# Altium schematic sheet PAGE2 - MCU.SchDoc
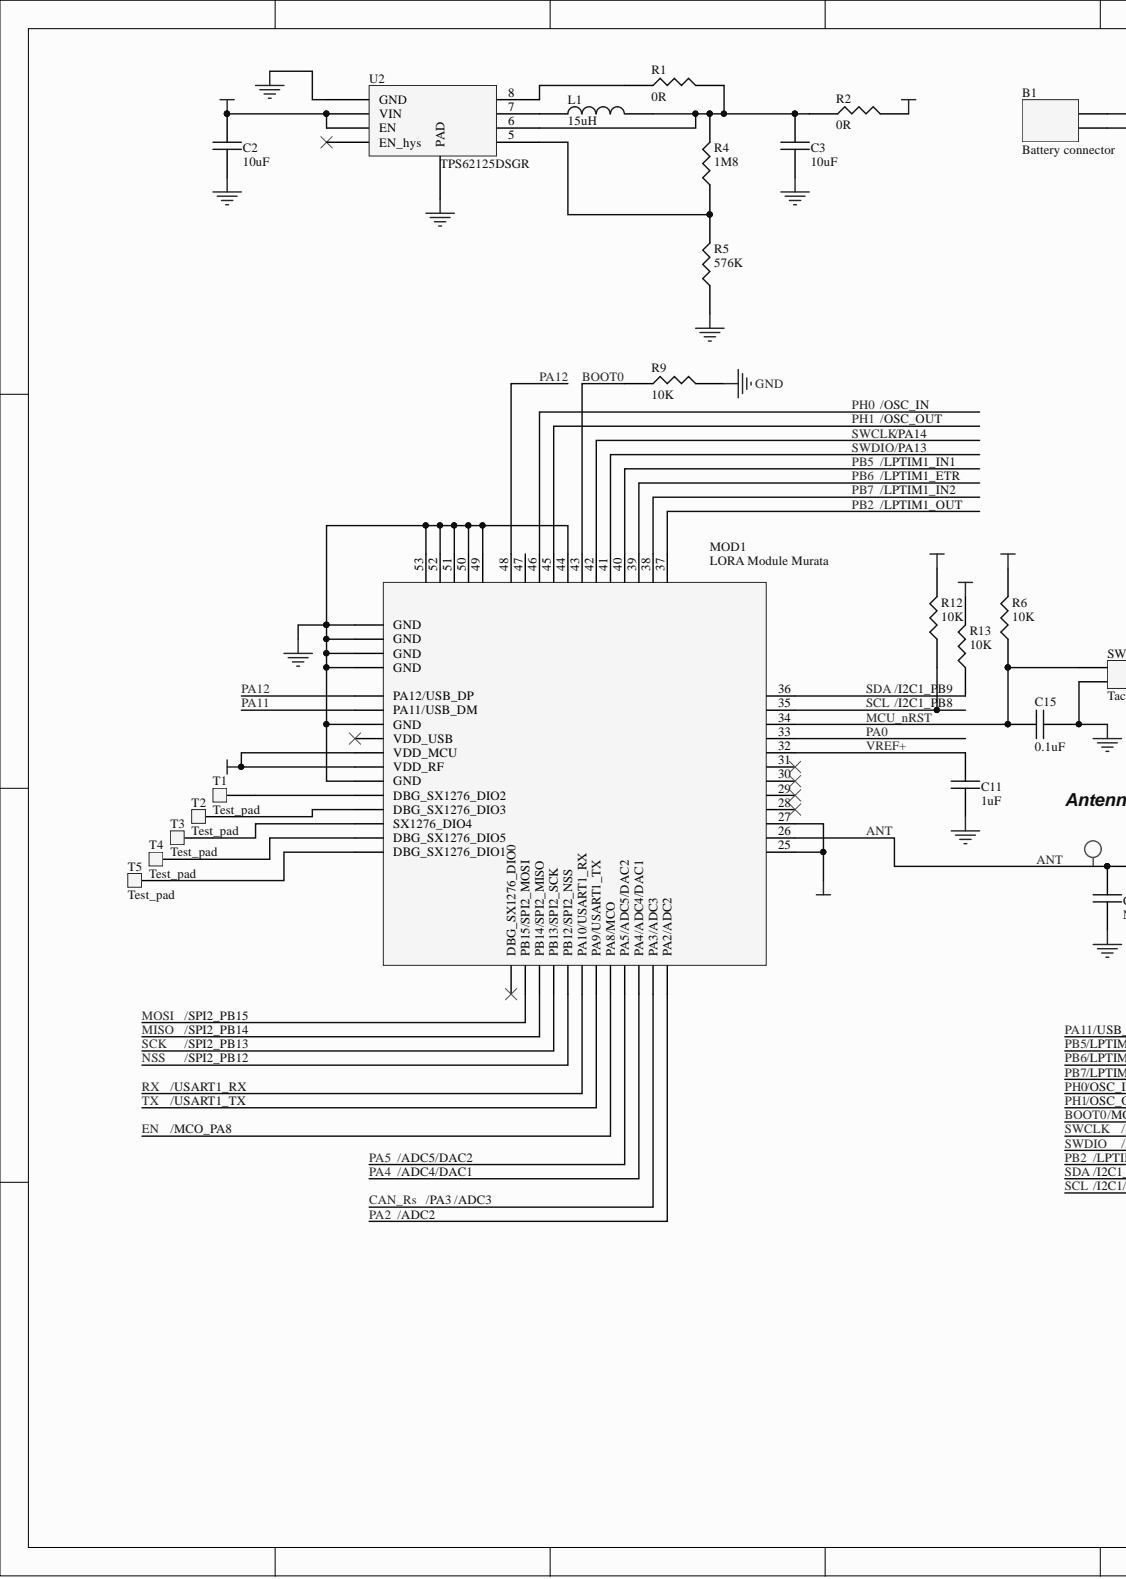
[diagram p0: PAGE2 - MCU.SchDoc, left]
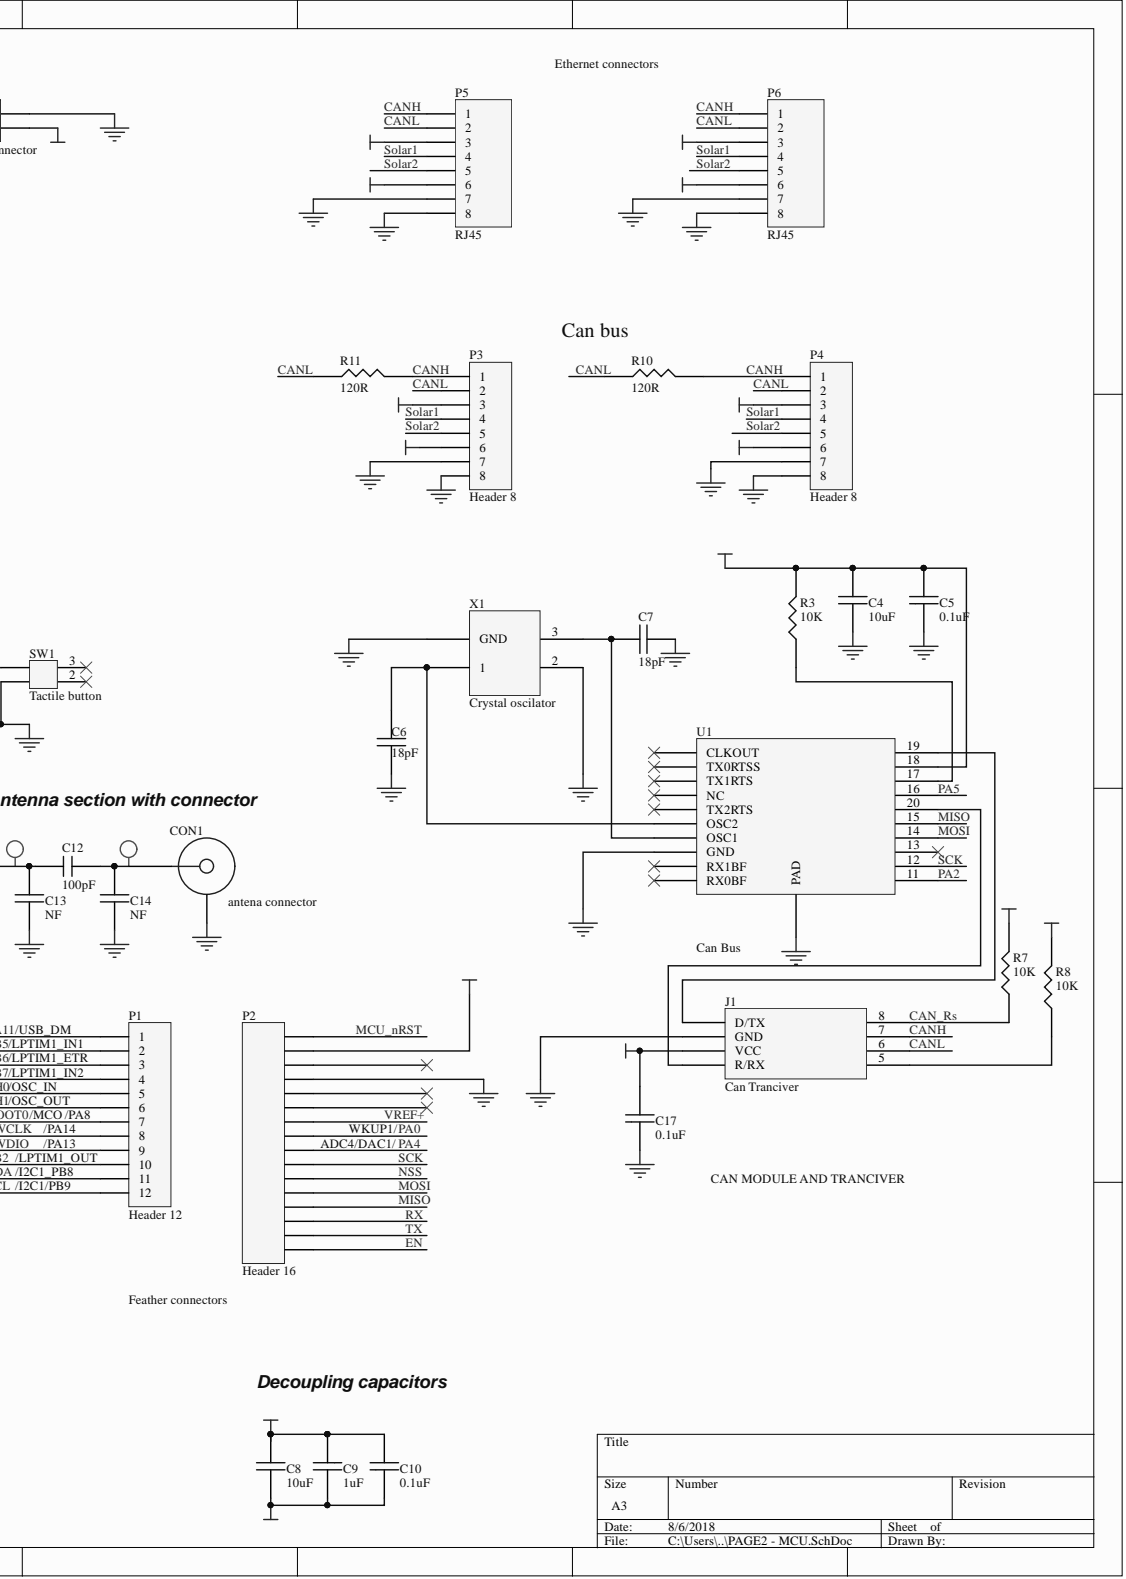
[diagram p1: PAGE2 - MCU.SchDoc, right]

## Parts
B1  Battery connector  [BAT_CON]
C2  10uF  [0603Cap]
C3  10uF  [0603Cap]
C4  10uF  [0603Cap]
C5  0.1uF  [0603Cap]
C6  18pF  [0603Cap]
C7  18pF  [0603Cap]
C8  10uF  [0603Cap]
C9  1uF  [0603Cap]
C10  0.1uF  [0603Cap]
C11  1uF  [0603Cap]
C12  100pF  [0402CAP]
C13  NF  [0402CAP]
C14  NF  [0402CAP]
C15  0.1uF  [0603Cap]
C17  0.1uF  [0603Cap]
CON1  antena connector  [uFL SMD CONNECTOR]
J1  Can Tranciver  [SOIC127-Can Tranciver]
L1  15uH  [Inductor 3.0x2.5]
MOD1  LORA Module Murata  Manufacturer: Murata  [Lora Module ES0]
P1  Header 12  [HDR1X12]
P2  Header 16  [HDR1X16]
P3  Header 8  [HDR1X8]
P4  Header 8  [HDR1X8]
P5  RJ45  [EThernet conn]
P6  RJ45  [EThernet conn]
R1  0R  [0603Res]
R2  0R  [0603Res]
R3  10K  [0603Res]
R4  1M8  [0603Res]
R5  576K  [0603Res]
R6  10K  [0603Res]
R7  10K  [0603Res]
R8  10K  [0603Res]
R9  10K  [0603Res]
R10  120R  [0603Res]
R11  120R  [0603Res]
R12  10K  [0603Res]
R13  10K  [0603Res]
SW1  Tactile button  [Tactile button]
T1  Test_pad  [Test_Pad]
T2  Test_pad  [Test_Pad]
T3  Test_pad  [Test_Pad]
T4  Test_pad  [Test_Pad]
T5  Test_pad  [Test_Pad]
U1  Can Bus  [QFN-Can Bus]
U2  TPS62125DSGR  [WSON-Voltage regulator]
X1  Crystal oscilator  [DFN-Crystal]

## Nets
+3V3: B1.1 C4.1 C5.2 C8.1 C9.1 C10.2 C17.2 J1.3(VCC) MOD1.5(VDD_MCU) MOD1.6(VDD_RF) P2.15 R2.2 R3.2 R6.2 R7.2 R8.2 R12.2 R13.2 U1.18
+12V: C2.1 P3.3 P3.6 P4.3 P4.6 P5.3 P5.6 P6.3 P6.6 U2.2(VIN) U2.3(EN)
GND: B1.2 C2.2 C3.2 C4.2 C5.1 C6.2 C7.1 C8.2 C9.2 C10.1 C11.1 C13.1 C14.1 C15.2 C17.1 CON1.2 J1.2(GND) MOD1.3(GND) MOD1.7(GND) MOD1.25 MOD1.27 MOD1.44 MOD1.49 MOD1.50 MOD1.51 MOD1.52 MOD1.53 MOD1.54(GND) MOD1.55(GND) MOD1.56(GND) MOD1.57(GND) P2.13 P3.7 P3.8 P4.7 P4.8 P5.7 P5.8 P6.7 P6.8 R5.1 R9.2 SW1.1 U1.8(GND) U1.21(PAD) U2.1(GND) U2.9(PAD) X1.2 X1.4(GND)
ANT: C12.1 C13.2 MOD1.26
BOOT0: MOD1.43 P1.7 R9.1
CAN_Rs: J1.8 MOD1.23(PA3/ADC3) R7.1
CANH: J1.7 P3.1 P4.1 P5.1 P6.1 R10.2 R11.2
CANL: J1.6 P3.2 P4.2 P5.2 P6.2 R10.1 R11.1
EN: MOD1.20(PA8/MCO) P2.1
MCU_nRST: C15.1 MOD1.34 P2.16 R6.1 SW1.4
MISO: MOD1.15(PB14/SPI2_MISO) P2.4 U1.15
MOSI: MOD1.14(PB15/SPI2_MOSI) P2.5 U1.14
NSS: MOD1.17(PB12/SPI2_NSS) P2.6
PA0: MOD1.33 P2.9
PA2: MOD1.24(PA2/ADC2) U1.11
PA4: MOD1.22(PA4/ADC4/DAC1) P2.8
PA5: MOD1.21(PA5/ADC5/DAC2) U1.16
PA11: MOD1.2(PA11/USB_DM) P1.1
PA12: MOD1.1(PA12/USB_DP) MOD1.48
PB2: MOD1.37 P1.10
PB5: MOD1.40 P1.2
PB6: MOD1.39 P1.3
PB7: MOD1.38 P1.4
PH0: MOD1.46 P1.5
PH1: MOD1.45 P1.6
RX: MOD1.18(PA10/USART1_RX) P2.3
SCK: MOD1.16(PB13/SPI2_SCK) P2.7 U1.12
SCL: MOD1.35 P1.12 R12.1
SDA: MOD1.36 P1.11 R13.1
Solar1: P3.4 P4.4 P5.4 P6.4
Solar2: P3.5 P4.5 P5.5 P6.5
SWCLK: MOD1.42 P1.8
SWDIO: MOD1.41 P1.9
TX: MOD1.19(PA9/USART1_TX) P2.2
VREF+: C11.2 MOD1.32 P2.10
NetC3_1: C3.1 L1.2 R1.2 R2.1 R4.2 U2.6
NetC6_1: C6.1 U1.6(OSC2) X1.1
NetC7_2: C7.2 U1.7(OSC1) X1.3
NetC12_2: C12.2 C14.2 CON1.1
NetJ1_1: J1.1(D/TX) U1.19
NetJ1_4: J1.4(R/RX) U1.20
NetJ1_5: J1.5 R8.1
NetL1_1: L1.1 U2.7
NetMOD1_8: MOD1.8(DBG_SX1276_DIO2) T1.1
NetMOD1_9: MOD1.9(DBG_SX1276_DIO3) T2.1
NetMOD1_10: MOD1.10(SX1276_DIO4) T3.1
NetMOD1_11: MOD1.11(DBG_SX1276_DIO5) T4.1
NetMOD1_12: MOD1.12(DBG_SX1276_DIO1) T5.1
NetR1_1: R1.1 U2.8
NetR3_1: R3.1 U1.17
NetR4_1: R4.1 R5.2 U2.5

Not connected: MOD1 4(VDD_USB) 13(DBG_SX1276_DIO0) 28 29 30 31 47; P2 11 12 14; SW1 2 3; U1 1(CLKOUT) 2(TX0RTSS) 3(TX1RTS) 4(NC) 5(TX2RTS) 9(RX1BF) 10(RX0BF) 13; U2 4(EN_hys)

## Library data of the parts
B1 = 1862: JST-PH 2-Pin SMT Right Angle Breakout Board; Manufacturer: Adafruit Industries; Component Kind: Standard; Manufacturer Part Number: 1862; Pricing 1: 1=1.5, 10=1.5, 50=1.5, 100=1.5, 1000=1.5, 10000=1.5 (USD); Stock 1: 115; Supplier 1: Mouser; Supplier Part Number 1: 485-1862; Library Name: Lora_feather.SchLib
C2-C4 C8 = 10uF Cap: MURATA         ZRB18AR61E106ME01L             SMD Multilayer Ceramic Capacitor, 0603 [1608 Metric], 10 F, 25 V,  20%, X5R, ZRA Series; Capacitance: 10µF; Voltage - Rated: 25V; Applications: Acoustic Noise Reduction; Category: Capacitors; Component Kind: Standard; ComponentLink1Description: http://farnell.com//datasheets/2243360.pdf; ComponentLink1URL: http://farnell.com//datasheets/2243360.pdf; ComponentLink2Description: http://www.farnell.com/datasheets/2163235.pdf; ComponentLink2URL: http://www.farnell.com/datasheets/2163235.pdf; ComponentLink3Description: http://search.murata.co.jp/Ceramy/image/img/A01X/G101/ENG/ZRB18AR61E106ME01-01.pdf; ComponentLink3URL: http://search.murata.co.jp/Ceramy/image/img/A01X/G101/ENG/ZRB18AR61E106ME01-01.pdf; ComponentLink4Description: http://www.farnell.com/datasheets/2243360.pdf; ComponentLink4URL: http://www.farnell.com/datasheets/2243360.pdf; Family: Ceramic Capacitors; Features: Interposer Termination; Height - Seated (Max): -; Lead Spacing: -; Lead Style: -; Manufacturer: Murata; Manufacturer Part Number: ZRB18AR61E106ME01L; Mounting Type: Surface Mount, MLCC; Operating Temperature: -55°C ~ 85°C; Package / Case: 0603 (1608 Metric); Packaging: Tape & Reel (TR); Ratings: -; Series: ZRB; Size / Dimension: 0.063" L x 0.031" W (1.60mm x 0.80mm); Stock 1: 0; Supplier 1: Farnell; Supplier Part Number 1: 2666614; Temperature Coefficient: X5R; Thickness (Max): 0.047" (1.20mm); Tolerance: ±20%; Library Name: Lora_feather.SchLib
C5 C10 C15 C17 = 0.1uF: Multilayer Ceramic Capacitors MLCC - SMD/SMT .1uF 50Volts X7R +/-10% 0603 STD; Manufacturer: Taiyo Yuden; Manufacturer Part Number: UMK107B7104KAHT; Capacitance: 0.1µF; Catalog Drawings: xMK Series_0603_0.8; Dynamic Catalog: M Series; Featured Product: Multilayer Chip Capacitors; Other Names: 587-3502-6; Tolerance: ±10%; Unit PriceUSD: M; Voltage - Rated: 50V; Applications: Automotive; Category: Capacitors; Component Kind: Standard; ComponentLink1Description: https://datasheet.ciiva.com/18697/mlcc01-hq-e-18697883.pdf; ComponentLink1URL: https://datasheet.ciiva.com/18697/mlcc01-hq-e-18697883.pdf; ComponentLink2Description: https://datasheet.ciiva.com/18697/mlcc01-hq-e-18697884.pdf; ComponentLink2URL: https://datasheet.ciiva.com/18697/mlcc01-hq-e-18697884.pdf; ComponentLink3Description: https://datasheet.ciiva.com/18697/mlcc01-hq-e-18697885.pdf; ComponentLink3URL: https://datasheet.ciiva.com/18697/mlcc01-hq-e-18697885.pdf; Family: Ceramic Capacitors; Minimum Quantity: 0.1µF; Mounting Type: Surface Mount, MLCC; Online Catalog: M Series; Operating Temperature: -55°C ~ 125°C; Package / Case: 0603 (1608 Metric); Package/Case: 0603 (1608 Metric); Packaging: Digi-Reel®; Pricing 1: 1=0.16, 10=0.054, 50=0.054, 100=0.037, 1000=0.025, 10000=0.018 (USD); Quantity Available: Digi-Reel®Alternate Packaging; Ratings: AEC-Q200; Series: M; Size / Dimension: 0.063" L x 0.031" W (1.60mm x 0.80mm); Standard Package: 1; Stock 1: 0; Supplier 1: Mouser; Supplier Part Number 1: 963-UMK107B7104KAHT; Temperature Coefficient: X7R; Thickness (Max): 0.035" (0.90mm); Library Name: Lora_feather.SchLib
C6 C7 = C0603C180K5RACTU: Multilayer Ceramic Capacitors MLCC - SMD/SMT .1uF 50Volts X7R +/-10% 0603 STD; Manufacturer: KEMET; Component Kind: Standard; Manufacturer Part Number: C0603C180K5RACTU; Pricing 1: 10=0.17, 100=0.0897, 500=0.0659, 1000=0.0559, 2000=0.0492, 4000=0.0416, 20000=0.0364 (EUR); Stock 1: 3407; Supplier 1: Farnell; Supplier Part Number 1: 2821151; Library Name: Lora_feather.SchLib
C9 C11 = 1uF: 1µF 50V Ceramic Capacitor X5R 0603 (1608 Metric) 0.063" L x 0.031" W (1.60mm x 0.80mm); Manufacturer: Murata; Manufacturer Part Number: GRT188R61H105KE13D; Tolerance: ±10%; Applications: Automotive; Capacitance: 1µF; Category: Capacitors; Component Kind: Standard; ComponentLink1Description: http://search.murata.co.jp/Ceramy/image/img/A01X/G101/ENG/GRT188R61H105KE13-01.pdf; ComponentLink1URL: http://search.murata.co.jp/Ceramy/image/img/A01X/G101/ENG/GRT188R61H105KE13-01.pdf; ComponentLink2Description: http://farnell.com//datasheets/2165959.pdf; ComponentLink2URL: http://farnell.com//datasheets/2165959.pdf; Family: Ceramic Capacitors; Features: -; Height - Seated (Max): -; Lead Spacing: -; Lead Style: -; Mounting Type: Surface Mount, MLCC; Operating Temperature: -55°C ~ 85°C; Package / Case: 0603 (1608 Metric); Packaging: Tape & Reel (TR); Pricing 1: 1=0.28, 10=0.187, 50=0.187, 100=0.095, 1000=0.058, 10000=0.044 (USD); Ratings: AEC-Q200; Series: GRT; Size / Dimension: 0.063" L x 0.031" W (1.60mm x 0.80mm); Stock 1: 119223; Supplier 1: Mouser; Supplier Part Number 1: 81-GRT188R61H105KE3D; Temperature Coefficient: X5R; Thickness (Max): 0.035" (0.90mm); Voltage - Rated: 50V; Library Name: Lora_feather.SchLib
C12 = 0F: MULTICOMP         MC0402B101K500CT            SMD Multilayer Ceramic Capacitor, MC Series, 100 pF,  10%, X7R, 50 V, 0402 [1005 Metric]; Product Range: MULTICOMP - MC Series; SVHC: To Be Advised; Voltage Rating: 50V; Capacitance: 100pF; Capacitance Tolerance: ± 10%; Capacitor Case Style: 0402 [1005 Metric]; Capacitor Terminals: SMD; Ceramic Capacitor Case: 0402 [1005 Metric]; Component Kind: Standard; ComponentLink1Description: https://datasheet.ciiva.com/36858/1870456-36858427.pdf; ComponentLink1URL: https://datasheet.ciiva.com/36858/1870456-36858427.pdf; ComponentLink2Description: https://datasheet.ciiva.com/36857/1825492-36857949.pdf; ComponentLink2URL: https://datasheet.ciiva.com/36857/1825492-36857949.pdf; ComponentLink3Description: https://datasheet.ciiva.com/36858/1870456-36858493.pdf; ComponentLink3URL: https://datasheet.ciiva.com/36858/1870456-36858493.pdf; Dielectric Characteristic: X7R; Manufacturer: Multicomp; Manufacturer Part Number: MC0402B101K500CT; MSL: -; Operating Temperature Max: 125°C; Operating Temperature Min: -55°C; Packaging: Tape & Reel; Pricing 1: 10=0.0386, 100=0.0239, 500=0.0142, 2500=0.0091 (EUR); Stock 1: 250; Supplier 1: Farnell; Supplier Part Number 1: 1758968; Library Name: Lora_feather.SchLib
C13 C14 = Cap0402: Component Kind: Standard; Library Name: Lora_feather.SchLib
CON1 = U.FL-R-SMT-1(10): CONN UMC JACK STR 50 OHM SMD; Manufacturer: Hirose; Body Finish: Silver; Body Material: Phosphor Bronze; Center Contact Material: Brass; Center Contact Plating: Gold; Connector Style: U.FL, Ultra Miniature Coaxial; Connector Type: Receptacle, Male Pin; Contact Termination: Solder; Dielectric Material: Liquid Crystal Polymer (LCP); Featured Product: U.FL Series Connectors; Frequency - Max: 6GHz; Impedance: 50 Ohm; Online Catalog: UMCC (Ultra Miniature Coax Connectors), U.FL Series; Other Names: H11891TR  Q5400621  U.FL-R-SMT-1 10  U.FL-R-SMT-1(10)-ND  UFLRSMT110; Cable Group: -; Category: Connectors, Interconnects; Component Kind: Standard; ComponentLink1Description: https://datasheet.ciiva.com/11762/0900766b8105243f-11762474.pdf; ComponentLink1URL: https://datasheet.ciiva.com/11762/0900766b8105243f-11762474.pdf; ComponentLink2Description: https://datasheet.ciiva.com/7651/91524-7651914.pdf; ComponentLink2URL: https://datasheet.ciiva.com/7651/91524-7651914.pdf; ComponentLink3Description: https://datasheet.ciiva.com/7651/91524-7651915.pdf; ComponentLink3URL: https://datasheet.ciiva.com/7651/91524-7651915.pdf; Family: Coaxial Connectors (RF); Fastening Type: Snap-On; Features: -; Housing Color: Beige; Ingress Protection: -; Manufacturer Part Number: U.FL-R-SMT-1(10); Mounting Type: Surface Mount; Operating Temperature: -40°C ~ 90°C; Packaging: Tape & Reel (TR); Pricing 1: 10=0.49, 100=0.476, 500=0.424, 1000=0.394, 2500=0.386, 5000=0.378 (GBP); Series: U.FL; Shield Termination: Solder; Standard Package: 2,500; Stock 1: 38546; Supplier 1: Farnell; Supplier Part Number 1: 1688077; Voltage Rating: -; Library Name: Lora_feather.SchLib
J1 = SN65HVD233D: IC 3.3V CAN TXRX 8-SOIC; Manufacturer: Texas Instruments; Maximum Data Rate: 1 Mbps; Maximum Single Supply Voltage: 3.6 V; Minimum Single Supply Voltage: 3 V; Number of Transceivers: 1; Power Down Mode: Standby|Sleep; Rad Hard: No; Standard Supported: ISO 11898; Category: Transceiver; Component Kind: Standard; ComponentLink1Description: https://datasheet.ciiva.com/1708/sn65hvd233-1708144.pdf; ComponentLink1URL: https://datasheet.ciiva.com/1708/sn65hvd233-1708144.pdf; ComponentLink2Description: https://datasheet.ciiva.com/6132/1766988-6132624.pdf; ComponentLink2URL: https://datasheet.ciiva.com/6132/1766988-6132624.pdf; ComponentLink3Description: https://datasheet.ciiva.com/30506/sn65hvd233d-30506946.pdf; ComponentLink3URL: https://datasheet.ciiva.com/30506/sn65hvd233d-30506946.pdf; ComponentLink4Description: https://datasheet.ciiva.com/19456/909986-19456776.pdf; ComponentLink4URL: https://datasheet.ciiva.com/19456/909986-19456776.pdf; ComponentLink5Description: https://datasheet.ciiva.com/41461/001186923-da-01-en-ic-3-3v-can-txrx-sn65hvd233d-soic-8-tid-41461470.pdf; ComponentLink5URL: https://datasheet.ciiva.com/41461/001186923-da-01-en-ic-3-3v-can-txrx-sn65hvd233d-soic-8-tid-41461470.pdf; Manufacturer Part Number: SN65HVD233D; Package: 8SOIC; Packaging: Rail / Tube; Pricing 1: 1=3.01, 10=2.7, 25=2.54, 50=2.38, 100=2.21, 250=2.08 (EUR); Stock 1: 681; Supplier 1: Farnell; Supplier Part Number 1: 1813337; Library Name: Lora_feather.SchLib
L1 = 15uH inductor: Fixed Inductors 15uH 0.28ohms 0.56A; Manufacturer: TDK; Manufacturer Part Number: VLF302515MT-150M; Current - Saturation: 500mA; DC Resistance (DCR): 330 mOhm Max; Dynamic Catalog: VLF-M and VLF-MT Series; Frequency - Test: 1MHz; Inductance: 15µH; Material - Core: Ferrite; Other Names: 445-9635-6; Shielding: Shielded; Tolerance: ±20%; Type: Wirewound; Unit PriceUSD: VLF-MT; Category: Inductors, Coils, Chokes; Component Kind: Standard; ComponentLink1Description: https://datasheet.ciiva.com/46449/1759590-46449866.pdf; ComponentLink1URL: https://datasheet.ciiva.com/46449/1759590-46449866.pdf; Current Rating: 500mA; Family: Fixed Inductors; Height - Seated (Max): 0.059" (1.50mm); Mounting Type: Surface Mount; Operating Temperature: -40°C ~ 105°C; Package / Case: Non Standard; Packaging: Digi-Reel®; Pricing 1: 5=1.46, 50=0.912, 250=0.895, 500=0.698, 1000=0.621, 2000=0.589, 10000=0.557 (EUR); Series: VLF-MT; Size / Dimension: 0.118" L x 0.098" W (3.00mm x 2.50mm); Standard Package: 1; Stock 1: 1162; Supplier 1: Farnell; Supplier Part Number 1: 2455319; Library Name: Lora_feather.SchLib
MOD1 = LRA Module ES0: Component Kind: Standard; Description: LRA Module ES0; Library Name: Integrated_Circuit.SchLib; Manufacturer P/N: LoRa Module ES0; Provided by: Murata
P1 = Header 12: Header, 12-Pin; Component Kind: Standard; LatestRevisionDate: 17-Jul-2002; LatestRevisionNote: Re-released for DXP Platform.; Library Name: Miscellaneous Connectors.IntLib; Signal Integrity: Connector; Publisher: Altium Limited
P2 = Header 16: Header, 16-Pin; Component Kind: Standard; LatestRevisionDate: 17-Jul-2002; LatestRevisionNote: Re-released for DXP Platform.; Library Name: Miscellaneous Connectors.IntLib; Signal Integrity: Connector; Publisher: Altium Limited
P3 P4 = Header 8: Header, 8-Pin; Component Kind: Standard; LatestRevisionDate: 17-Jul-2002; LatestRevisionNote: Re-released for DXP Platform.; Library Name: Miscellaneous Connectors.IntLib; Signal Integrity: Connector; Publisher: Altium Limited
P5 P6 = 44620-0001: MODULAR JACK; Manufacturer: Molex; Body Orientation: Right Angle; Gender: RCP; Number of Contacts: 8; Pitch: 2.04 mm; Rad Hard: No; Category: Connector Telephone and Telecom; Component Kind: Standard; ComponentLink1Description: https://datasheet.ciiva.com/26929/getdatasheetpartid-767379-26929525.pdf; ComponentLink1URL: https://datasheet.ciiva.com/26929/getdatasheetpartid-767379-26929525.pdf; ComponentLink2Description: https://datasheet.ciiva.com/19472/2040276-19472615.pdf; ComponentLink2URL: https://datasheet.ciiva.com/19472/2040276-19472615.pdf; ComponentLink3Description: https://datasheet.ciiva.com/30213/71510446200001-modular-jacks-plug-30213975.pdf; ComponentLink3URL: https://datasheet.ciiva.com/30213/71510446200001-modular-jacks-plug-30213975.pdf; ComponentLink4Description: https://datasheet.ciiva.com/4562/0446200001-modular-jacks-plug-145952-4562632.pdf; ComponentLink4URL: https://datasheet.ciiva.com/4562/0446200001-modular-jacks-plug-145952-4562632.pdf; ComponentLink5Description: https://datasheet.ciiva.com/16074/832720446200001-modular-jacks-plug-16074650.pdf; ComponentLink5URL: https://datasheet.ciiva.com/16074/832720446200001-modular-jacks-plug-16074650.pdf; ComponentLink6Description: https://datasheet.ciiva.com/1107/66995-1107778.pdf; ComponentLink6URL: https://datasheet.ciiva.com/1107/66995-1107778.pdf; ComponentLink7Description: https://datasheet.ciiva.com/9393/0446200001-modular-jacks-plug-9393019.pdf; ComponentLink7URL: https://datasheet.ciiva.com/9393/0446200001-modular-jacks-plug-9393019.pdf; ComponentLink8Description: https://datasheet.ciiva.com/16649/0446200001-modular-jacks-plug-16649582.pdf; ComponentLink8URL: https://datasheet.ciiva.com/16649/0446200001-modular-jacks-plug-16649582.pdf; Manufacturer Part Number: 44620-0001; Mounting: Through Hole; Pricing 1: 1=0.689, 50=0.675, 100=0.67, 250=0.669, 500=0.668, 1000=0.667 (EUR); Stock 1: 1202; Supplier 1: Farnell; Supplier Part Number 1: 2782009; Termination Method: Solder; Type: RJ-45; Library Name: Lora_feather.SchLib
R1 R2 = 0R: RES SMD 0.0OHM JUMPER 1/10W 0603; Manufacturer: Vishay; Manufacturer Part Number: CRCW06030000Z0EA; EDA / CAD Models: Download from Accelerated Designs; Kits: 541-1419-ND  - RESISTOR KIT 0-1M 1/10W 6100PCS; Online Catalog: CRCW - Automotive Series; Other Names: 541-0.0GCT; Power (Watts): 0.1W, 1/10W; Resistance (Ohms): 0.0; Category: Resistors; Component Kind: Standard; ComponentLink1Description: https://datasheet.ciiva.com/2461/dcrcwe3-2461968.pdf; ComponentLink1URL: https://datasheet.ciiva.com/2461/dcrcwe3-2461968.pdf; ComponentLink2Description: https://datasheet.ciiva.com/2461/dcrcwe3-2461969.pdf; ComponentLink2URL: https://datasheet.ciiva.com/2461/dcrcwe3-2461969.pdf; ComponentLink3Description: https://datasheet.ciiva.com/2461/dcrcwe3-2461970.pdf; ComponentLink3URL: https://datasheet.ciiva.com/2461/dcrcwe3-2461970.pdf; ComponentLink4Description: https://datasheet.ciiva.com/8340/dcrcwe3-8340197.pdf; ComponentLink4URL: https://datasheet.ciiva.com/8340/dcrcwe3-8340197.pdf; ComponentLink5Description: https://datasheet.ciiva.com/16941/dcrcw-16941302.pdf; ComponentLink5URL: https://datasheet.ciiva.com/16941/dcrcw-16941302.pdf; ComponentLink6Description: https://datasheet.ciiva.com/16199/dcrcwe3-16199063.pdf; ComponentLink6URL: https://datasheet.ciiva.com/16199/dcrcwe3-16199063.pdf; ComponentLink7Description: https://datasheet.ciiva.com/16725/70201119-16725175.pdf; ComponentLink7URL: https://datasheet.ciiva.com/16725/70201119-16725175.pdf; ComponentLink8Description: https://datasheet.ciiva.com/26316/fld-crcw-e3-data-en-26316615.pdf; ComponentLink8URL: https://datasheet.ciiva.com/26316/fld-crcw-e3-data-en-26316615.pdf; ComponentLink9Description: https://datasheet.ciiva.com/5544/dcrcwe3-109170-5544344.pdf; ComponentLink9URL: https://datasheet.ciiva.com/5544/dcrcwe3-109170-5544344.pdf; ComponentLink10Description: https://datasheet.ciiva.com/7841/1720486-7841816.pdf; ComponentLink10URL: https://datasheet.ciiva.com/7841/1720486-7841816.pdf; ComponentLink11Description: https://datasheet.ciiva.com/7841/1720486-7841817.pdf; ComponentLink11URL: https://datasheet.ciiva.com/7841/1720486-7841817.pdf; ComponentLink12Description: https://datasheet.ciiva.com/7841/125364-7841818.pdf; ComponentLink12URL: https://datasheet.ciiva.com/7841/125364-7841818.pdf; ComponentLink13Description: https://datasheet.ciiva.com/16721/70201119-16721450.pdf; ComponentLink13URL: https://datasheet.ciiva.com/16721/70201119-16721450.pdf; Composition: Thick Film; Family: Chip Resistor - Surface Mount; Features: Automotive AEC-Q200; Height: 0.020" (0.50mm); Number of Terminations: 2; Operating Temperature: -55°C ~ 155°C; Package / Case: 0603 (1608 Metric); Packaging: Cut Tape (CT); Pricing 1: 5000=0.0046 (EUR); Series: CRCW; Size / Dimension: 0.061" L x 0.033" W (1.55mm x 0.85mm); Standard Package: 1; Stock 1: 1145000; Supplier 1: Farnell; Supplier Device Package: 0603 (1608 Metric); Supplier Part Number 1: 2122112; Temperature Coefficient: -; Tolerance: Jumper; Library Name: Lora_feather.SchLib
R3 R6-R9 R12 R13 = 10K: TE CONNECTIVITY / NEOHM         CPF0603F10KC1             SMD Chip Resistor, Thin Film, 10 kohm, 50 V, 0603 [1608 Metric], 63 mW,  1%, CPF Series; Manufacturer: TE Connectivity Neohm; Climatic Category: 55/125/55; External Depth: 0.45mm; External Length / Height: 1.6mm; External Width: 0.8mm; No. of Pins: 2; Overload Voltage: 120V; Power Rating: 63mW; Product Range: TE CONNECTIVITY - CPF Series; Resistance: 10kohm; SVHC: To Be Advised; Temperature Coefficient: ± 50ppm/°C; Voltage Rating: 60V; Component Kind: Standard; ComponentLink1Description: https://datasheet.ciiva.com/24494/29261eng-ds-1773200-f-24494553.pdf; ComponentLink1URL: https://datasheet.ciiva.com/24494/29261eng-ds-1773200-f-24494553.pdf; ComponentLink2Description: https://datasheet.ciiva.com/7789/eng-ds-1773200-j-7789563.pdf; ComponentLink2URL: https://datasheet.ciiva.com/7789/eng-ds-1773200-j-7789563.pdf; ComponentLink3Description: https://datasheet.ciiva.com/16902/eng-ds-1773200-i-16902044.pdf; ComponentLink3URL: https://datasheet.ciiva.com/16902/eng-ds-1773200-i-16902044.pdf; ComponentLink4Description: https://datasheet.ciiva.com/22692/1786775-22692861.pdf; ComponentLink4URL: https://datasheet.ciiva.com/22692/1786775-22692861.pdf; Manufacturer Part Number: CPF0603F10KC1; MSL: (Not Available); Operating Temperature Max: 125°C; Operating Temperature Min: -55°C; Operating Temperature Range: -55°C to +125°C; Package / Case: 0603; Packaging: Cut Tape; Resistance Tolerance: ± 1%; Resistor Case Style: 0603 [1608 Metric]; Resistor Element Material: Thin Film; Resistor Element Type: Thin Film; Resistor Mounting: SMD; Series: CPF; Stock 1: 0; Supplier 1: Farnell; Supplier Part Number 1: 1527581RL; Library Name: Lora_feather.SchLib
R4 = 1.8Mohm Res: Manufacturer: Multicomp; Manufacturer Part Number: MCHVR03FTFX1804; Component Kind: Standard; Pricing 1: 10=0.0383, 100=0.0291, 500=0.0206, 1000=0.0165, 2500=0.0138, 5000=0.0119, 25000=0.0085 (EUR); Stock 1: 4880; Supplier 1: Farnell; Supplier Part Number 1: 2825653; Library Name: Lora_feather.SchLib
R5 = 576K Res: Res Thick Film 0603 576K Ohm 1% 0.1W(1/10W) ±100ppm/C Molded SMD Automotive Punched T/R; Manufacturer: Panasonic; Manufacturer Part Number: ERJ-3EKF5763V; EDA / CAD Models: Download from Accelerated Designs; Kits: RSM5H-ND  - RES KIT 100K-1M 1/10W 4850PCS; Online Catalog: ERJ Series; Other Names: ERJ-3EKF5763 ERJ3EKF5763 P576KHCT; Power (Watts): 0.1W, 1/10W; Temperature Coefficient: ±100ppm/°C; Category: Resistors; Component Kind: Standard; ComponentLink1Description: https://datasheet.ciiva.com/9181/aoa0000ce2-9181015.pdf; ComponentLink1URL: https://datasheet.ciiva.com/9181/aoa0000ce2-9181015.pdf; ComponentLink2Description: https://datasheet.ciiva.com/22668/1678782-22668424.pdf; ComponentLink2URL: https://datasheet.ciiva.com/22668/1678782-22668424.pdf; ComponentLink3Description: https://datasheet.ciiva.com/9181/aoa0000ce2-9181017.pdf; ComponentLink3URL: https://datasheet.ciiva.com/9181/aoa0000ce2-9181017.pdf; ComponentLink4Description: https://datasheet.ciiva.com/24031/aoa0000ce2-24031849.pdf; ComponentLink4URL: https://datasheet.ciiva.com/24031/aoa0000ce2-24031849.pdf; ComponentLink5Description: https://datasheet.ciiva.com/46420/1678782-46420010.pdf; ComponentLink5URL: https://datasheet.ciiva.com/46420/1678782-46420010.pdf; ComponentLink6Description: https://datasheet.ciiva.com/16768/70260748-16768539.pdf; ComponentLink6URL: https://datasheet.ciiva.com/16768/70260748-16768539.pdf; ComponentLink7Description: https://datasheet.ciiva.com/16778/70260748-16778396.pdf; ComponentLink7URL: https://datasheet.ciiva.com/16778/70260748-16778396.pdf; ComponentLink8Description: https://datasheet.ciiva.com/30145/aoa0000ce2-30145500.pdf; ComponentLink8URL: https://datasheet.ciiva.com/30145/aoa0000ce2-30145500.pdf; Composition: Thick Film; Family: Chip Resistor - Surface Mount; Features: Automotive AEC-Q200; Height: 0.022" (0.55mm); Number of Terminations: 2; Operating Temperature: -55°C ~ 155°C; Package / Case: 0603 (1608 Metric); Packaging: Cut Tape (CT); Pricing 1: 10=0.0416, 100=0.0243, 500=0.0181, 1000=0.0162 (EUR); Resistance (Ohms): 576k; Series: ERJ; Size / Dimension: 0.063" L x 0.031" W (1.60mm x 0.80mm); Standard Package: 1; Stock 1: 39830; Supplier 1: Farnell; Supplier Device Package: 0603; Supplier Part Number 1: 2303298; Tolerance: ±1%; Library Name: Lora_feather.SchLib
R10 R11 = 120R: RES SMD 120 OHM 1% 1/10W 0603; Manufacturer: Vishay; Manufacturer Part Number: CRCW0603120RFKEA; Brand: Vishay / Dale; Power Rating: 100 mW; Resistance: 120 Ohms; Temperature Coefficient: 100 PPM / K; Unit Weight: 0.000071 oz; Voltage Rating: 75 V; Case Code - in: 0603; Case Code - mm: 1608; Component Kind: Standard; ComponentLink1Description: https://datasheet.ciiva.com/11811/0900766b80d98afc-11811523.pdf; ComponentLink1URL: https://datasheet.ciiva.com/11811/0900766b80d98afc-11811523.pdf; ComponentLink2Description: https://datasheet.ciiva.com/2513/dcrcwe3-2513575.pdf; ComponentLink2URL: https://datasheet.ciiva.com/2513/dcrcwe3-2513575.pdf; ComponentLink3Description: https://datasheet.ciiva.com/2513/dcrcwe3-2513576.pdf; ComponentLink3URL: https://datasheet.ciiva.com/2513/dcrcwe3-2513576.pdf; ComponentLink4Description: https://datasheet.ciiva.com/26316/fld-crcw-e3-data-en-26316568.pdf; ComponentLink4URL: https://datasheet.ciiva.com/26316/fld-crcw-e3-data-en-26316568.pdf; ComponentLink5Description: https://datasheet.ciiva.com/2513/dcrcwe3-2513577.pdf; ComponentLink5URL: https://datasheet.ciiva.com/2513/dcrcwe3-2513577.pdf; ComponentLink6Description: https://datasheet.ciiva.com/5544/dcrcwe3-109170-5544139.pdf; ComponentLink6URL: https://datasheet.ciiva.com/5544/dcrcwe3-109170-5544139.pdf; ComponentLink7Description: https://datasheet.ciiva.com/7777/1533075-7777275.pdf; ComponentLink7URL: https://datasheet.ciiva.com/7777/1533075-7777275.pdf; ComponentLink8Description: https://datasheet.ciiva.com/7777/1697337-7777276.pdf; ComponentLink8URL: https://datasheet.ciiva.com/7777/1697337-7777276.pdf; ComponentLink9Description: https://datasheet.ciiva.com/16725/70201119-16725181.pdf; ComponentLink9URL: https://datasheet.ciiva.com/16725/70201119-16725181.pdf; Factory Pack Quantity: 5000; Height: 0.45 mm; Length: 1.55 mm; Operating Temperature Range: - 55 C to + 155 C; Package / Case: 0603 (1608 metric); Packaging: Reel; Product: Thick Film Resistors SMD; Product Category: Thick Film Resistors - SMD; RoHS: Details; Series: CRCW; Stock 1: 0; Supplier 1: Farnell; Supplier Part Number 1: 1652832RL; Termination Style: SMD/SMT; Tolerance: 1 %; Width: 0.85 mm; Library Name: Lora_feather.SchLib
SW1 = KMR221NGLFS: SWITCH TACTILE SPST-NO 0.05A 32V; Manufacturer: ITT C&K; Actuator Length: 0.5 mm; Actuator Style: Round Button; Body Orientation: Straight; Contact Rating @ Voltage: 1 A; Dielectric Strength: >=250 Vrms; Ground Terminal: No; Illumination Type: N/R; Mechanical Life: 200000 Cycles; Minimum Insulation Resistance: >=1000 MOhm; Operating Force: 2 N; Pole Throw Configuration: SPST; Switch Configuration: N.O.; Actuator Color: N/A; Base Material: N/A; Component Kind: Standard; ComponentLink1Description: https://datasheet.ciiva.com/16443/kmr221nglfs-16443038.pdf; ComponentLink1URL: https://datasheet.ciiva.com/16443/kmr221nglfs-16443038.pdf; ComponentLink2Description: https://datasheet.ciiva.com/2900/kmr2-9aug12-2900169.pdf; ComponentLink2URL: https://datasheet.ciiva.com/2900/kmr2-9aug12-2900169.pdf; ComponentLink3Description: https://datasheet.ciiva.com/2900/kmr2-9aug12-2900170.pdf; ComponentLink3URL: https://datasheet.ciiva.com/2900/kmr2-9aug12-2900170.pdf; ComponentLink4Description: https://datasheet.ciiva.com/16695/kmr2-9aug12-16695914.pdf; ComponentLink4URL: https://datasheet.ciiva.com/16695/kmr2-9aug12-16695914.pdf; ComponentLink5Description: https://datasheet.ciiva.com/2900/kmr2-9aug12-2900171.pdf; ComponentLink5URL: https://datasheet.ciiva.com/2900/kmr2-9aug12-2900171.pdf; Contact Material: Silver; Contact Plating: Silver; Dimension: 2.8 x 4.6 x 1.9 mm; ECCN: EAR99; Electrical Life: N/A; Family: KMR 2; Housing Material: N/A; HTS: 8536501199; Manufacturer Part Number: KMR221NGLFS; Maximum AC Voltage Rating: N/A; Maximum DC Voltage Rating: 32 V; Mounting: Surface Mount,Gull Wing; Operating Temperature: -40 to 85 C; Packaging: Tape and Reel; Part No: KMR221NGLFS; Pricing 1: 10=0.278, 500=0.264, 1000=0.208, 5000=0.204, 10000=0.2 (GBP); Product Weight: N/A; RoHS: Yes; Seal Description: N/A; Seal Location: N/A; Stock 1: 5345; Supplier 1: C&K Components; Supplier Part Number 1: 2845727; Supplier Standard Pack: 7,000; Type: Micro-Miniature; Library Name: Lora_feather.SchLib
T1-T5 = Test_pad: Test point; Component Kind: Standard; Library Name: Lora_feather.SchLib
U1 = MCP2515T-I/ML: IC CAN CTLR W/SPI 2.0B 20-QFN; Manufacturer: Microchip; Data Rate: 1000Kbps; Number of Transceivers: 1; Operating Supply Voltage (Max): 5.5V; Operating Supply Voltage (Min): 2.7V; Operating Supply Voltage (Typ): 3.3/5V; Power Down Mode: Sleep/Standby; Standard Supported: CAN 2.0B; Supply Current: 10mA; Category: Controller; Component Kind: Standard; ComponentLink1Description: https://datasheet.ciiva.com/11212/21801f-11212833.pdf; ComponentLink1URL: https://datasheet.ciiva.com/11212/21801f-11212833.pdf; ComponentLink2Description: https://datasheet.ciiva.com/1695/21801g-1695396.pdf; ComponentLink2URL: https://datasheet.ciiva.com/1695/21801g-1695396.pdf; ComponentLink3Description: https://datasheet.ciiva.com/1695/21801g-1695397.pdf; ComponentLink3URL: https://datasheet.ciiva.com/1695/21801g-1695397.pdf; ComponentLink4Description: https://datasheet.ciiva.com/1695/21801g-1695398.pdf; ComponentLink4URL: https://datasheet.ciiva.com/1695/21801g-1695398.pdf; ComponentLink5Description: https://datasheet.ciiva.com/16454/filehandler-aspxddocname-en011733-16454232.pdf; ComponentLink5URL: https://datasheet.ciiva.com/16454/filehandler-aspxddocname-en011733-16454232.pdf; Manufacturer Part Number: MCP2515T-I/ML; Mounting: Surface Mount; Operating Temperature (Max): 85C; Operating Temperature (Min): -40C; Operating Temperature Classification: Industrial; Package Type: QFN EP; Packaging: Tape and Reel; Pin Count: 20; Pricing 1: 1=1.66, 10=1.53, 25=1.39, 100=1.27 (EUR); Stock 1: 3152; Supplier 1: Farnell; Supplier Part Number 1: 2857781; Library Name: Lora_feather.SchLib
U2 = TPS62125DSGR: Voltage Regulators - Switching Regulators 3V-17V, 300mA Buck Converter; Manufacturer: Texas Instruments; Featured Product: WEBENCH Design Center; Frequency - Switching: 1MHz; Number of Outputs: 1; Output Type: Adjustable; PWM Type: Hybrid; Synchronous Rectifier: Yes; Voltage - Input: 3 V ~ 17 V; Voltage - Output: 1.2 V ~ 10 V; Category: Integrated Circuits (ICs); Component Kind: Standard; ComponentLink1Description: https://datasheet.ciiva.com/28375/tps62125-28375971.pdf; ComponentLink1URL: https://datasheet.ciiva.com/28375/tps62125-28375971.pdf; ComponentLink2Description: https://datasheet.ciiva.com/6598/tps62125-6598733.pdf; ComponentLink2URL: https://datasheet.ciiva.com/6598/tps62125-6598733.pdf; Current - Output: 300mA; Family: PMIC - Voltage Regulators - DC DC Switching Regulators; Manufacturer Part Number: TPS62125DSGR; Operating Temperature: -40°C ~ 85°C; Series: DCS-Control™; Standard Package: 3,000; Stock 1: 0; Supplier 1: Farnell; Supplier Part Number 1: 2769414RL; Type: Step-Down (Buck); Library Name: Lora_feather.SchLib
X1 = ABM3B-8.000MHZ-10-1-U-T: 8MHz ±10ppm Crystal 10pF 200 Ohm -10°C ~ 60°C  Surface Mount 4-SMD, No Lead (DFN, LCC); Manufacturer: Abracon; ESR (Equivalent Series Resistance): 200 Ohm; Operating Mode: Fundamental; Category: Crystals and Oscillators; Component Kind: Standard; ComponentLink1Description: https://datasheet.ciiva.com/855/abm3b-855873.pdf; ComponentLink1URL: https://datasheet.ciiva.com/855/abm3b-855873.pdf; ComponentLink2Description: https://datasheet.ciiva.com/855/abm3b-855874.pdf; ComponentLink2URL: https://datasheet.ciiva.com/855/abm3b-855874.pdf; ComponentLink3Description: https://datasheet.ciiva.com/855/abm3b-855875.pdf; ComponentLink3URL: https://datasheet.ciiva.com/855/abm3b-855875.pdf; ComponentLink4Description: https://datasheet.ciiva.com/16378/abm3b-16378027.pdf; ComponentLink4URL: https://datasheet.ciiva.com/16378/abm3b-16378027.pdf; ComponentLink5Description: https://datasheet.ciiva.com/3175/abm3b-3175853.pdf; ComponentLink5URL: https://datasheet.ciiva.com/3175/abm3b-3175853.pdf; Family: Crystals; Frequency: 8MHz; Frequency Stability: ±10ppm; Frequency Tolerance: ±10ppm; Height: 0.043" (1.10mm); Load Capacitance: 10pF; Manufacturer Part Number: ABM3B-8.000MHZ-10-1-U-T; Mounting Type: Surface Mount; Operating Temperature: -10°C ~ 60°C; Package / Case: 4-SMD, No Lead (DFN, LCC); Packaging: Tape & Reel (TR); Ratings: -; Series: ABM3B; Size / Dimension: 0.197" L x 0.126" W (5.00mm x 3.20mm); Stock 1: 0; Supplier 1: Farnell; Supplier Part Number 1: 2467817RL; Type: MHz Crystal; Library Name: Lora_feather.SchLib
